# Altium schematic sheet LoraFonte.SchDoc
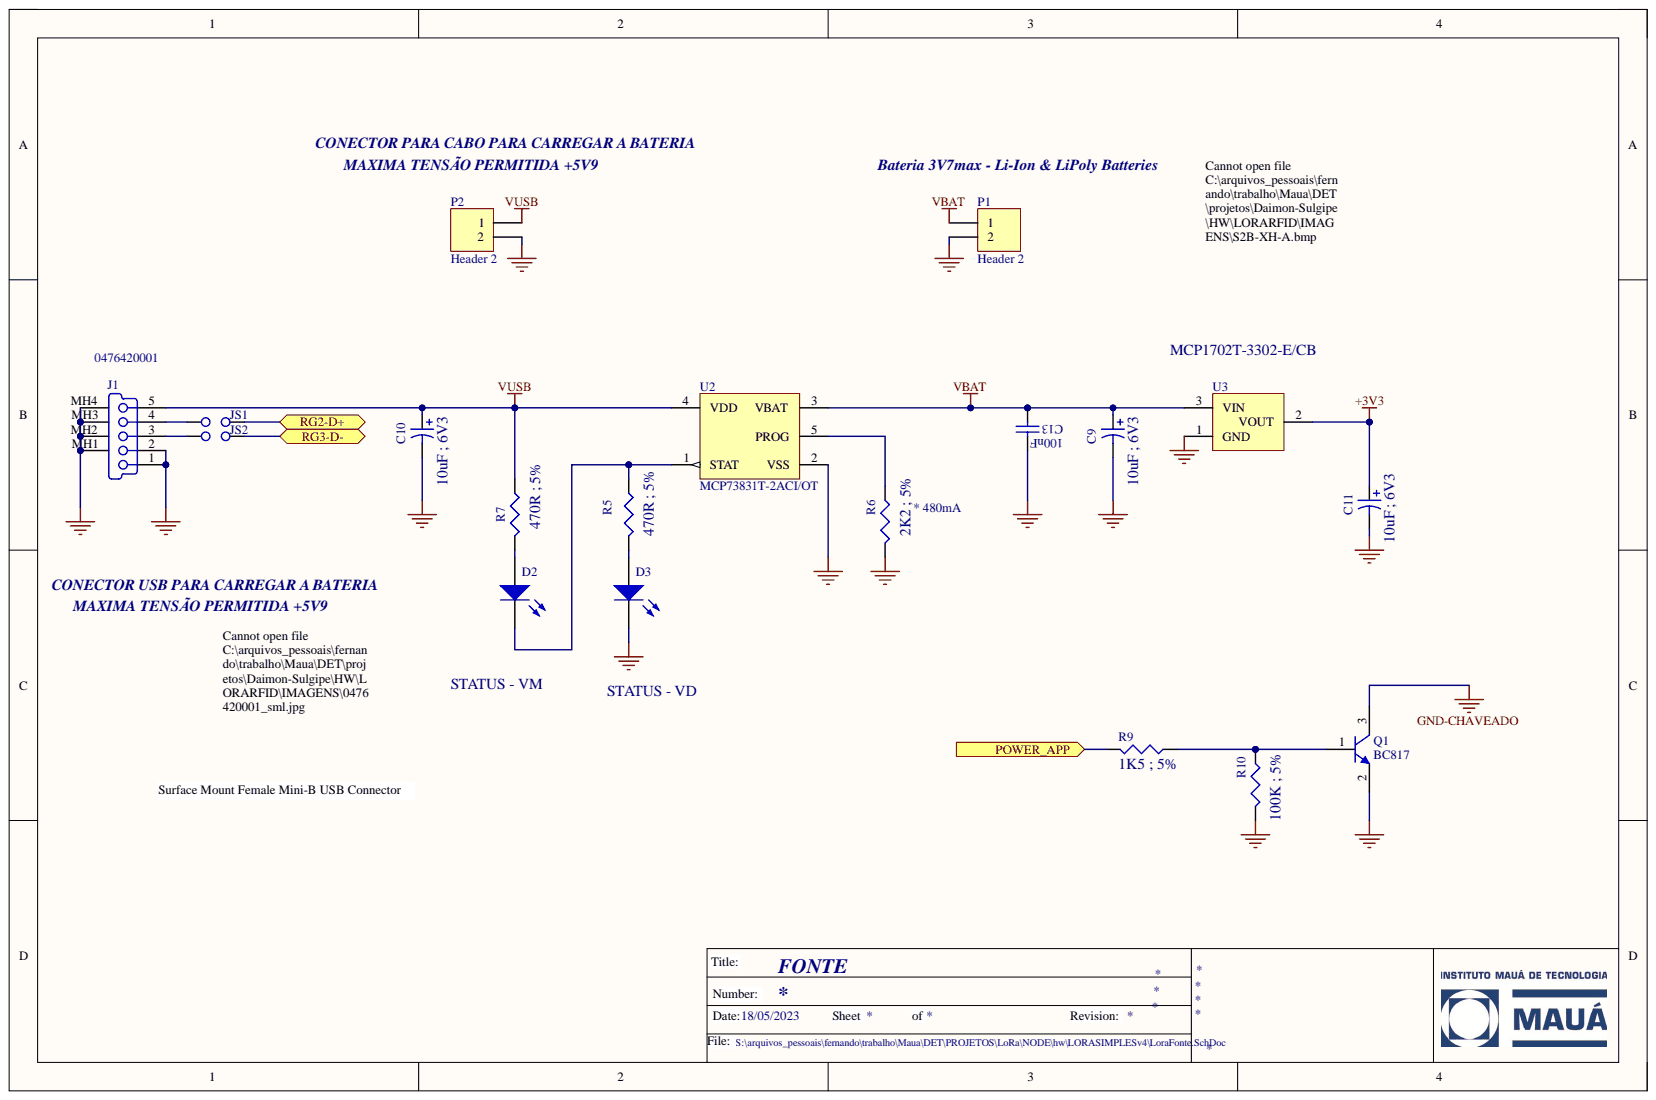
[diagram p0: LoraFonte.SchDoc]

## Parts
C9  Valor: 10uF ; 6V3  [CASE-A]  (hidden comment: 02.0103)
C10  Valor: 10uF ; 6V3  [CASE-A]  (hidden comment: 02.0103)
C11  Valor: 10uF ; 6V3  [CASE-A]  (hidden comment: 02.0103)
C13  value 100nF  [0603 - CAP]  (hidden comment: 02.0404)
D2  AMARELO  [LED 0603 AM]  (value not drawn)
D3  AMARELO  [LED 0603 AM]  (value not drawn)
J1  0476420001  [481900001]
JS1  JUMPER SOLDA 2 VIAS  [JUMPER SOLDA 2 VIAS]  (value not drawn)
JS2  JUMPER SOLDA 2 VIAS  [JUMPER SOLDA 2 VIAS]  (value not drawn)
P1  Header 2  [HDR1X2]
P2  Header 2  [HDR1X2]
Q1  Part number: BC817  [SOT23-3N FAIRCHILD]  (hidden comment: 05.0068)
R5  Valor: 470R ; 5%  [0603]
R6  Valor: 2K2 ; 5%  [0603]
R7  Valor: 470R ; 5%  [0603]
R9  Valor: 1K5 ; 5%  [0603]
R10  Valor: 100K ; 5%  [0603]
U2  MCP73831T-2ACI/OT  [SOT-23-OT5_N]
U3  07.0792  [SOT23-3N - MCP17XXX]  (value not drawn)

## Ports
POWER_APP, RG2-D+, RG3-D-

## Nets
+3V3: C11.1 U3.2
GND: C9.2 C10.2 C11.2 C13.1 D3.2 J1.1 J1.2 J1.MH1 J1.MH2 J1.MH3 J1.MH4 P1.2 P2.2 Q1.2 R6.2 R10.2 U2.2(VSS) U3.1
GND-CHAVEADO: Q1.3
VBAT: C9.1 C13.2 P1.1 U2.3(VBAT) U3.3
VUSB: C10.1 J1.5 P2.1 R7.1 U2.4(VDD)
POWER_APP: R9.2 ; port POWER_APP
RG2-D+: JS1.2 ; port RG2-D+
RG3-D-: JS2.2 ; port RG3-D-
NetD2_1: D2.1 R7.2
NetD2_2: D2.2 R5.1 U2.1(STAT)
NetD3_1: D3.1 R5.2
NetJ1_3: J1.3 JS2.1
NetJ1_4: J1.4 JS1.1
NetQ1_1: Q1.1 R9.1 R10.1
NetR6_1: R6.1 U2.5(PROG)

## Library data of the parts
C9-C11 = Capacitor de tântalo: Grupo: 02; Component Kind: Standard; Description: Capacitor de tântalo; LatestRevisionDate: 17-Jul-2002; LatestRevisionNote: Re-released for DXP Platform.; Library Name: Miscellaneous Devices.IntLib; PackageReference: POLAR0.8; Published: 8-Jun-2000; Publisher: Altium Limited
C13 = Capacitor Cerâmico SMD: Component Kind: Standard; Description: Capacitor Cerâmico SMD; LatestRevisionDate: 17-Jul-2002; LatestRevisionNote: Re-released for DXP Platform.; Library Name: Miscellaneous Devices.IntLib; PackageReference: C0805; Published: 8-Jun-2000; Publisher: Altium Limited
D2 = LED2: Led; Encapsulamento: 0603; Fornecedor: Opoen; Grupo: 09; Valor: STATUS - VM; Component Kind: Standard; Library Name: Miscellaneous Devices.IntLib; Simulation: LED2
D3 = LED2: Led; Encapsulamento: 0603; Fornecedor: Opoen; Grupo: 09; Valor: STATUS - VD; Component Kind: Standard; Library Name: Miscellaneous Devices.IntLib; Simulation: LED2
J1 = 0481900001: USB On-The-Go (OTG) Mini-B Receptacle, Right Angle, SMT, 0.80mm (.031") Pitch, Solder Tabs with Back Cover, Recessed Type; PCB_Layout: Complies with Manufacturer's Recommendation.; Component Kind: Standard; ComponentLink1Description: Manufacturer Link; ComponentLink1URL: http://www.molex.com/; ComponentLink2Description: Datasheet; ComponentLink2URL: http://www.molex.com/cmc_upload/0/000/895/958/SD-48190-001RevA.pdf; DatasheetVersion: revA, May-2004; Library Name: Molex Serial Bus Mini USB.IntLib; PackageDescription: USB Mini-B, Sinking Type, SM; 5 Position; Right Angle, Body 11.45 x 11.8 x 3.9 mm (LxWxH); PackageReference: 481900001; PackageVersion: May-2004; Published: 21-Sep-2007; Signal Integrity: USB Mini-B; Publisher: Altium Limited
JS1 JS2 = JUMPER SOLDA 2 VIAS: JUMPER DE SOLDA 2 VIAS; Component Kind: Standard; Library Name: Miscellaneous Devices.IntLib
P1 P2 = Header 2: Header, 2-Pin; Component Kind: Standard; LatestRevisionDate: 17-Jul-2002; LatestRevisionNote: Re-released for DXP Platform.; Library Name: Miscellaneous Connectors.IntLib; Signal Integrity: Connector; Publisher: Altium Limited
Q1 = BC817-25: Transistor NPN; Component Kind: Standard; ComponentLink1Description: Manufacturer Link; ComponentLink1URL: http://www.fairchildsemi.com/; ComponentLink2Description: Discontinuation Notice; ComponentLink2URL: http://www.fairchildsemi.com/obsolete/obs.jsp; DatasheetDocument: 1997; LatestRevisionDate: 17-Jul-2002; LatestRevisionNote: Re-released for DXP Platform.; Library Name: FSC Discrete BJT.IntLib; PackageDocument: Sep-1998; PackageReference: SOT-23A; Published: 8-Jun-2000; Publisher: Altium Limited
R5-R7 R9 R10 = Res3: Resistor; Encapsulamento: 0402; Grupo: 01; Component Kind: Standard; LatestRevisionDate: 04-Mar-2005; LatestRevisionNote: PCB Footprint replaced.; Library Name: Miscellaneous Devices.IntLib; PackageReference: 0402; Published: 8-Jun-2000; Signal Integrity: Res; Simulation: RESISTOR; Publisher: Altium Limited
U2 = MCP73831T-2ACI/OT: Miniature Single-Cell, Fully Integrated Li-Ion, Li-Polymer Charge Management Controller, 4.2V, 5-Pin SOT-23, Industrial Temperature, Tape and Reel; Manufacturer: Microchip; Code_IPC: SOT23-5; Component Kind: Standard; ComponentLink1Description: Manufacturer URL; ComponentLink1URL: http://www.microchip.com/; ComponentLink2Description: Datasheet; ComponentLink2URL: http://ww1.microchip.com/downloads/en/DeviceDoc/21984e.pdf; ComponentLink3Description: Package Specification; ComponentLink3URL: http://www.microchip.com/stellent/groups/techpub_sg/documents/packagingspec/en012702.pdf; DatasheetVersion: revE, Sep-2008; Library Name: Microchip Power Management Battery Management.IntLib; Mounting Technology: Surface Mount; PackageDescription: 5-Lead Plastic Small Outline Transistor (OT) [SOT-23]; PackageReference: SOT-23-OT5; PackageVersion: revBB, Aug-2009; PartNumber: MCP73831T-2ACI/OT; Supplier 1: Digi-Key; Supplier 2: Digi-Key; Supplier 3: Digi-Key; Supplier 4: Farnell; Supplier 5: Farnell; Supplier 6: Newark; Supplier 7: Mouser; Supplier Part Number 1: MCP73831T-2ACI/OTTR-ND; Supplier Part Number 2: MCP73831T-2ACI/OTCT-ND; Supplier Part Number 3: MCP73831T-2ACI/OTDKR-ND; Supplier Part Number 4: 1332158; Supplier Part Number 5: 1332158RL; Supplier Part Number 6: 56K7045; Supplier Part Number 7: 579-MCP73831T-2ACIOT
U3 = MCP1702T-3302-E/CB: Regulador de tensâo; Encapsulamento: SOT-89; Fabricante: MICROCHIP; Fornecedor: INTERTEK; Grupo: 07; Component Kind: Standard; Part number: MCP1702T-3302-E/CB; Library Name: REGULADORES DE TENSAO.IntLib
